# Altium schematic sheet AVR Programmer.SchDoc
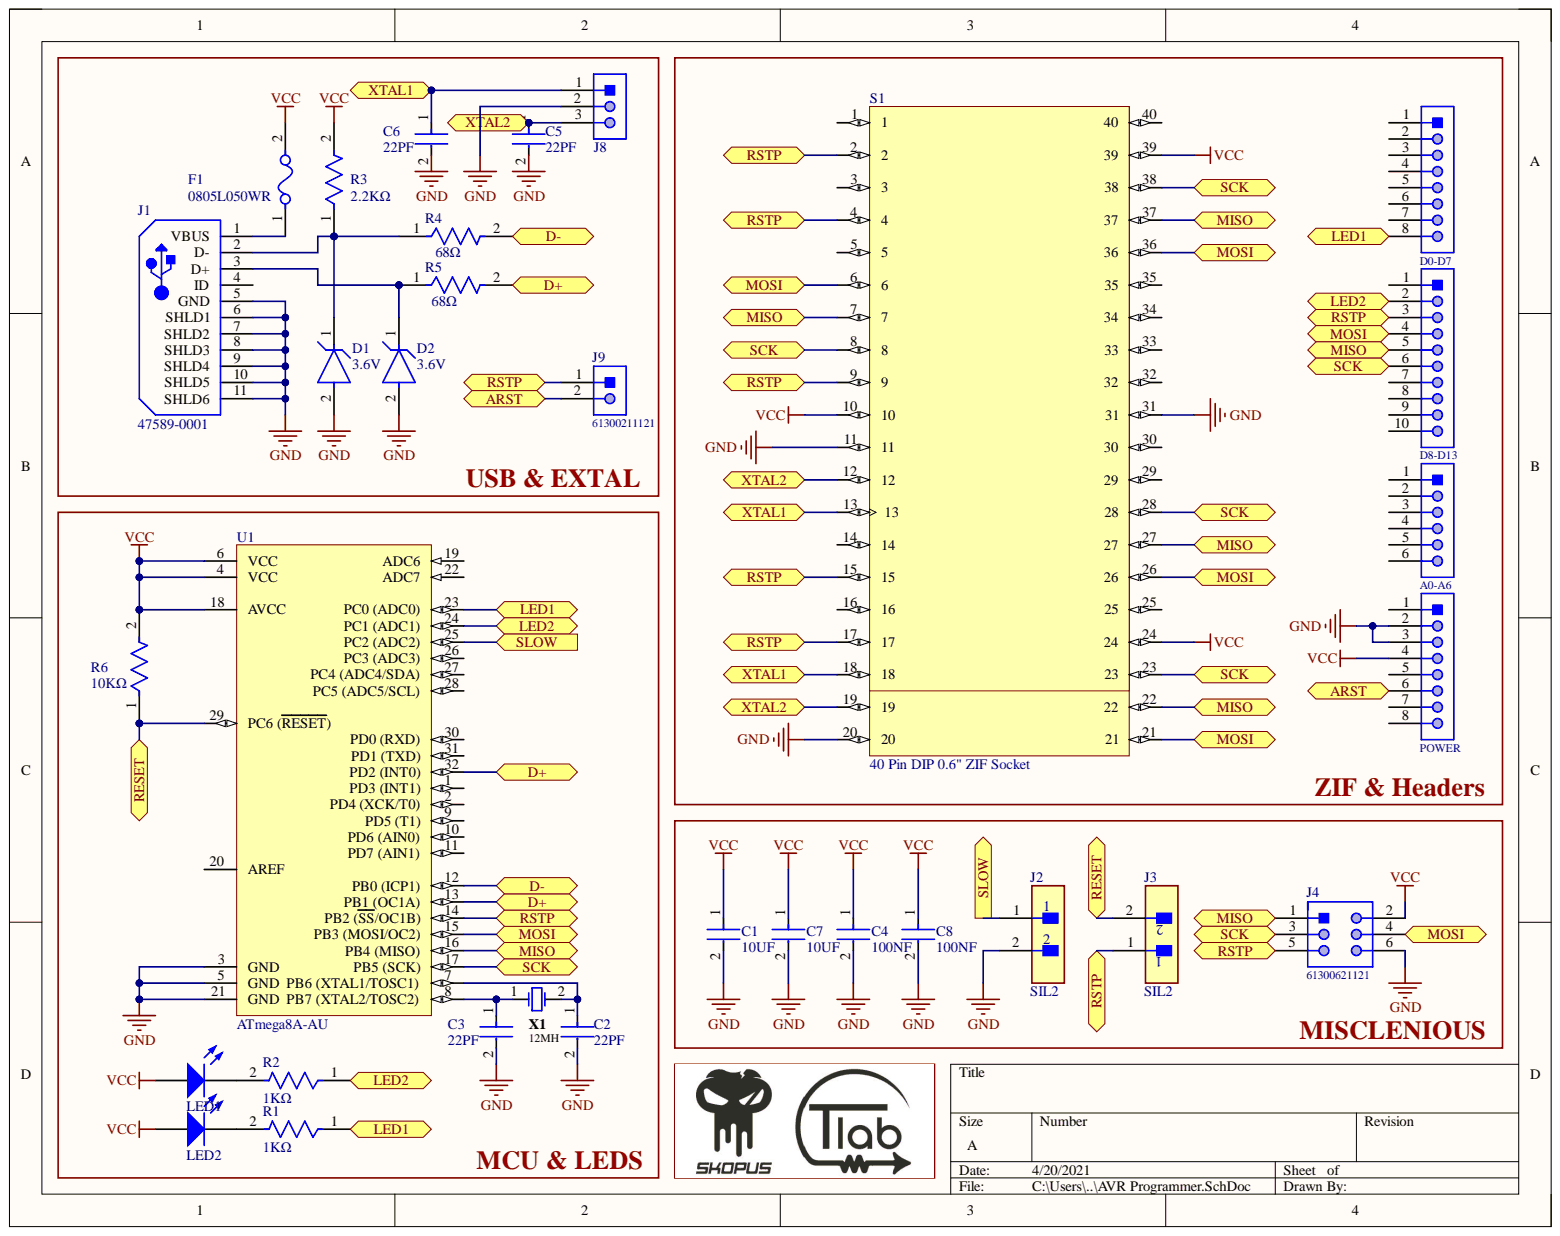
[diagram p0: AVR Programmer.SchDoc]

## Parts
C1  10UF  [FP-C0805C-DN-MFG]
C2  22PF  [FP-CC0805-0_85-MFG]
C3  22PF  [FP-CC0805-0_85-MFG]
C4  100NF  [FP-C0805C-DN-MFG]
C5  22PF  [FP-CC0805-0_85-MFG]
C6  22PF  [FP-CC0805-0_85-MFG]
C7  10UF  [FP-C0805C-DN-MFG]
C8  100NF  [FP-C0805C-DN-MFG]
D1  3.6V  [FP-6_560-5005_01-4-MFG]
D2  3.6V  [FP-6_560-5005_01-4-MFG]
F1  0805L050WR  [FP-0805L-MFG]
J1  47589-0001  [MOLX-47589-0001_V]
J2  SIL2  [FP-M22-2510205-MFG]
J3  SIL2  [FP-M22-2510205-MFG]
J4  D0-D7  [61300811121]
J4  61300621121  [61300621121]
J5  D8-D13  [61301011121]
J6  A0-A6  [61300611121]
J7  POWER  [61300811121]
J8  61300311121  [61300311121]  (value not drawn)
J9  61300211121  [61300211121]
LED1  (no value)  [0805_A]
LED2  150080SS75000  [0805_A]  (value not drawn)
R1  1KΩ  [FP-CRCW0805-e3-IPC_C]
R2  1KΩ  [FP-CRCW0805-e3-IPC_C]
R3  2.2KΩ  [FP-CRCW0805-e3-IPC_C]
R4  68Ω  [FP-CRCW0805-e3-IPC_C]
R5  68Ω  [FP-CRCW0805-e3-IPC_C]
R6  10KΩ  [FP-CRCW0805-e3-IPC_C]
S1  40 Pin DIP 0.6" ZIF Socket  [40P6]
U1  ATmega8A-AU  [32A_N]
X1  12MH  [FOX-FOXSLF-2_V]

## Ports
ARST, D+, D-, LED1, LED2, MISO, MOSI, RESET, RSTP, SCK, SLOW, XTAL1, XTAL2

## Nets
GND: C1.2 C2.2 C3.2 C4.2 C5.2 C6.2 C7.2 C8.2 D1.2 D2.2 J1.5(GND) J1.6(SHLD1) J1.7(SHLD2) J1.8(SHLD3) J1.9(SHLD4) J1.10(SHLD5) J1.11(SHLD6) J2.2 J4.6 J7.2 J7.3 J8.2 S1.11 S1.20 S1.31 U1.3(GND) U1.5(GND) U1.21(GND)
VCC: C1.1 C4.1 C7.1 C8.1 F1.2 J4.2 J7.4 LED1.2 LED2.2 R3.2 R6.2 S1.10 S1.24 S1.39 U1.4(VCC) U1.6(VCC) U1.18(AVCC)
ARST: J7.6 J9.2 ; port ARST
LED1: J4.8 R1.1 U1.23(PC0_(ADC0)) ; port LED1
LED2: J5.2 R2.1 U1.24(PC1_(ADC1)) ; port LED2
MISO: J4.1 J5.5 S1.7 S1.22 S1.27 S1.37 U1.16(PB4_(MISO)) ; port MISO
MOSI: J4.4 J5.4 S1.6 S1.21 S1.26 S1.36 U1.15(PB3_(MOSI/OC2)) ; port MOSI
RESET: J3.2 R6.1 U1.29(PC6_(RESET)) ; port RESET
RSTP: J3.1 J4.5 J5.3 J9.1 S1.2 S1.4 S1.9 S1.15 S1.17 U1.14(PB2_(SS/OC1B)) ; port RSTP
SCK: J4.3 J5.6 S1.8 S1.23 S1.28 S1.38 U1.17(PB5_(SCK)) ; port SCK
SLOW: J2.1 U1.25(PC2_(ADC2)) ; port SLOW
XTAL1: C6.1 J8.1 S1.13 S1.18 ; port XTAL1
XTAL2: C5.1 J8.3 S1.12 S1.19 ; port XTAL2
NetC2_1: C2.1 U1.7 X1.2
NetC3_1: C3.1 U1.8 X1.1
NetD1_1: D1.1 J1.2(D-) R3.1 R4.1
NetD2_1: D2.1 J1.3(D+) R5.1
NetF1_1: F1.1 J1.1(VBUS)
NetLED1_1: LED1.1 R2.2
NetLED2_1: LED2.1 R1.2
NetR4_2: R4.2 U1.12(PB0_(ICP1))
NetR5_2: R5.2 U1.13(PB1_(OC1A)) U1.32(PD2_(INT0))

Not connected: J1 4(ID); J4 7; J5 1 7 8 9 10; J6 1 2 3 4 5 6; J7 1 5 7 8; S1 1 3 5 14 16 25 29 30 32 33 34 35 40; U1 1(PD3_(INT1)) 2(PD4_(XCK/T0)) 9(PD5_(T1)) 10(PD6_(AIN0)) 11(PD7_(AIN1)) 19(ADC6) 20(AREF) 22(ADC7) 26(PC3_(ADC3)) 27(PC4_(ADC4/SDA)) 28(PC5_(ADC5/SCL)) 30(PD0_(RXD)) 31(PD1_(TXD))

## Library data of the parts
C1 C7 = CMP-2007-00235-2: CAP CER 1000PF 50V C0G/NP0 0805; Manufacturer: KEMET; Manufacturer Part Number: C0805C102J5GACTU; Capacitance: 1,000 pF; Material: Ceramic; Temperature Characteristic: C0G; Temperature Coefficient: 30ppm/°C; Tolerance: 0.05; Value: 1,000 pF; Automotive: No; Category: Cap; Component Kind: Standard; ComponentLink2Description: Datasheet; ComponentLink2URL: https://content.kemet.com/datasheets/KEM_C1003_C0G_SMD.pdf; Device Class L1: Passive Components; Device Class L2: Capacitors; Device Class L3: Ceramic Capacitors; Digikey Description: CAP CER 1000PF 50V C0G/NP0 0805; Height: 0.88mm; Ipc Land Pattern Name: CAPC200125X78; Lead Free: Yes; Library Name: Altium Content Vault; Mouser Description: Multilayer Ceramic Capacitors MLCC - SMD/SMT 50volts 1000pF C0G 5%; Octopart: https://octopart.com/c0805c102j5gactu-kemet-97757; Package: C0805C-DN; RoHS: TRUE; Temperature: -55°C to +125°C; Temperature Range High: +125°C; Temperature Range Low: -55°C; Voltage Rating: 50 V
C2 C3 C5 C6 = CMP-2100-03606-3: CAP CER 0.1UF 50V X7R 0805; Manufacturer: Yageo; Manufacturer Part Number: CC0805KRX7R9BB104; Capacitance: 100 nF; Material: Ceramic; Tolerance: 0.1; Value: 100 nF; Automotive: No; Category: Cap; Component Kind: Standard; ComponentLink2Description: Datasheet; ComponentLink2URL: http://www.yageo.com/documents/recent/UPY-GPHC_Y5V_6.3V-to-50V_8.pdf; Device Class L1: Passive Components; Device Class L2: Capacitors; Device Class L3: Ceramic Capacitors; Digikey Description: CAP CER 0.1UF 50V X7R 0805; Footprint Url: http://www.yageo.com/exep/pages/download/literatures/UPY-C_GEN_24.pdf; Height: 0.95mm; Ipc Land Pattern Name: CAPC200125X85; Lead Free: Yes; Library Name: Altium Content Vault; Mouser Description: Multilayer Ceramic Capacitors MLCC - SMD/SMT 100nF 50V X7R 10%; Octopart: https://octopart.com/cc0805krx7r9bb104-yageo-192993; Package: CC0805-0.85; RoHS: TRUE; Temperature: -30°C to +85°C; Temperature Characteristic: X7R; Temperature Range High: +85°C; Temperature Range Low: -30°C; Voltage Rating: 50 V
C4 C8 = CMP-2007-00403-2: CAP CER 680PF 50V X7R 0805; Manufacturer: KEMET; Manufacturer Part Number: C0805C681K5RACTU; Material: Ceramic; Temperature Coefficient: 30ppm/°C; Tolerance: 0.1; Automotive: No; Capacitance: 680 pF; Category: Cap; Component Kind: Standard; ComponentLink2Description: Datasheet; ComponentLink2URL: https://content.kemet.com/datasheets/KEM_C1003_C0G_SMD.pdf; Device Class L1: Passive Components; Device Class L2: Capacitors; Device Class L3: Ceramic Capacitors; Digikey Description: CAP CER 680PF 50V X7R 0805; Height: 0.88mm; Ipc Land Pattern Name: CAPC200125X78; Lead Free: Yes; Library Name: Altium Content Vault; Mouser Description: 0805 C 680pF Ceramic Multilayer Capacitor; 50 VDC; +125degC; X7R Dielec; +/-10%; Octopart: https://octopart.com/c0805c681k5ractu-kemet-124671; Package: C0805C-DN; RoHS: TRUE; Temperature: -55°C to +125°C; Temperature Characteristic: X7R; Temperature Range High: +125°C; Temperature Range Low: -55°C; Value: 680 pF; Voltage Rating: 50 V
D1 D2 = CMP-02541-000010-1: DIODE ZENER 5.1V 500MW SOD80; Manufacturer: Vishay Semiconductor Diodes Division; Manufacturer Part Number: TZMC5V1-GS08; Automotive Grade: Grade 0; Forward Current: 200mA; Max Junction Temp: +175°C; Max Reverse Leakage Current: 0.1uA; Max Zener Voltage: 5.4V; Reverse Voltage: 1V; Zener Impedance: 60Ω; Automotive: Yes; Category: Diode; Component Kind: Standard; ComponentLink2Description: Datasheet; ComponentLink2URL: https://www.vishay.com/docs/84122/tzm.pdf; Device Class L1: Discrete Semiconductors; Device Class L2: Diodes; Device Class L3: Zener Diodes; Digikey Description: DIODE ZENER 5.1V 500MW SOD80; Height: 1.6mm; Lead Free: Yes; Library Name: Altium Content Vault; Mouser Description: TZM Series 500 mW 5.1 V 200 mA Small Signal Zener Diode - SOD-80; Nom Zener Voltage: 5.1V; Octopart: https://octopart.com/tzmc5v1-gs08-vishay-1003274; Package: 6.560-5005.01-4; Power Dissipation: 500mW; RoHS: TRUE; Temperature: to +175°C; Temperature Range High: +175°C
F1 = CMP-08606-000023-1: PTC RESET FUSE 6V 500MA 0805; Manufacturer: Littelfuse Inc.; Current Rating: 100A; Hold Current: 0.5A; Resistance: 0.15-0.85Ω; Trip Current: 1A; Trip Time: 0.1s; Value: 0.15-0.85Ω; Ambient Temperature Range High: +85°C; Ambient Temperature Range Low: -40°C; Automotive: No; Category: Fuse; Component Kind: Standard; ComponentLink2Description: Datasheet; ComponentLink2URL: https://www.littelfuse.com/~/media/electronics/datasheets/resettable_ptcs/littelfuse_ptc_0805l_datasheet.pdf.pdf; Device Class L1: Circuit Protection; Device Class L2: PTC Resettable Fuses; Device Class L3: unset; Digikey Description: PTC RESET FUSE 6V 500MA 0805; Height: 1.25mm; Lead Free: Yes; Library Name: Altium Content Vault; Manufacturer Part Number: 0805L050WR; Mouser Description: PTC Resettable Fuse 0.5A(hold) 1A(trip) 6VDC 100A 0.5W 0.1s 0.15Ohm SMD Solder Pad 0805 T/R; Octopart: https://octopart.com/0805l050wr-littelfuse-673858; Package: 0805L; RoHS: TRUE; Temperature: -40°C to +85°C; Temperature Range High: +85°C; Temperature Range Low: -40°C; Voltage Rating: 6V
J1 = CMP-2000-05610-1: Right Angle Female Type AB Micro USB Connector, 30 V, 1.8 A, -30 to 85 degC, RoHS, Tape and Reel; Brand: Molex; Connector Type: MicroUSBTypeABreceptacle; Current Rating: 1.8A; Number of Contacts: 5Contact; Number of Ports: 1Port; Voltage Rating: 30VAC; : 0475890001; Color: Black; Component Kind: Standard; Contact Material: CopperAlloy; Contact Plating: Gold; Datasheet URL: http://www.molex.com/webdocs/datasheets/pdf/en-us/0475890001_IO_CONNECTORS.pdf; Datasheet Version: 12/2016; Gender: Female; Library Name: Altium Content Vault; Manufacturer: Molex; Manufacturer URL: http://www.molex.com/; Mounting Angle: Right; Mounting Technology: SurfaceMount; Operating Temperature Range: -20Cto+85C; Package Description: Micro USB Connector, Pitch 0.65 mm, 5 Positions, Body 7.5 x 5.6 mm; Package Reference: 47589-0001; Package URL: http://www.molex.com/pdm_docs/sd/475890001_sd.pdf; Packaging: Reel; Product: MicroUSBTypeABConnectors; Product Category: USBConnectors; RoHS: True; Series: 47589; Standard: USB; Termination Style: SMD/SMT
J2 J3 = CMP-15831-000005-1: CONN HEADER VERT 2POS 2MM; Manufacturer: Harwin; Manufacturer Part Number: M22-2510205; Conn Gender: Male; Conn Orientation: Vertical; Current Rating: 2A; Number Of Contacts: 2; Automotive: No; Category: Conn; Component Kind: Standard; ComponentLink2Description: Datasheet; ComponentLink2URL: https://cdn.harwin.com/pdfs/C018XX_M22_Series_Connectors.pdf; Contact Material: Gold,Nickel; Device Class L1: Connectors; Device Class L2: Headers and Wire Housings; Device Class L3: unset; Digikey Description: CONN HEADER VERT 2POS 2MM; Footprint Url: https://cdn.harwin.com/pdfs/M22-251.pdf; Height: 5.45mm; Lead Free: Yes; Library Name: Altium Content Vault; Mouser Description: Headers & Wire Housings 2 PIN SIL VERTICAL GOLD PIN HEADER; Number Of Rows: 1; Octopart: https://octopart.com/m22-2510205-harwin-2538221; Package: 0; Pitch: 2mm; RoHS: TRUE; Temperature: -40°C to +105°C; Temperature Range High: +105°C; Temperature Range Low: -40°C
J4 J7 = CMP-1502-01076-1: THT Vertical Pin Header WR-PHD, Pitch 2.54 mm, Single Row, 8 pins; Manufacturer: Wurth Elektronik; Contact Resistance: 20mOhm; Gender: Male; Pin Number: 8; Pitch: 2.54mm; Rated Current: 3A; Withstanding Voltage: 500VAC; Working Voltage: 250VAC; Component Kind: Standard; Datasheet URL: https://katalog.we-online.de/em/datasheet/6130xx11121.pdf; Library Name: Altium Content Vault; Manufacturer URL: http://www.we-online.de; Mounting Technology: THT; Operating Temperature: -40to105degC; Orientation: Vertical; Package Reference: 61300811121; Packaging: Bag; Part Number: 61300811121; Row Number: Single; Publisher: WurthElektronik
J4 = CMP-1502-01072-1: THT Vertical Pin Header WR-PHD, Pitch 2.54 mm, Dual Row, 6 pins; Manufacturer: Wurth Elektronik; Contact Resistance: 20mOhm; Gender: Male; Pin Number: 6; Pitch: 2.54mm; Rated Current: 3A; Withstanding Voltage: 500VAC; Working Voltage: 250VAC; Component Kind: Standard; Datasheet URL: https://katalog.we-online.de/em/datasheet/6130xx21121.pdf; Library Name: Altium Content Vault; Manufacturer URL: http://www.we-online.de; Mounting Technology: THT; Operating Temperature: -40to105degC; Orientation: Vertical; Package Reference: 61300621121; Packaging: Bag; Part Number: 61300621121; Row Number: Dual; Publisher: WurthElektronik
J5 = CMP-1502-01082-1: THT Vertical Pin Header WR-PHD, Pitch 2.54 mm, Single Row, 10 pins; Manufacturer: Wurth Elektronik; Contact Resistance: 20mOhm; Gender: Male; Pin Number: 10; Pitch: 2.54mm; Rated Current: 3A; Withstanding Voltage: 500VAC; Working Voltage: 250VAC; Component Kind: Standard; Datasheet URL: https://katalog.we-online.de/em/datasheet/6130xx11121.pdf; Library Name: Altium Content Vault; Manufacturer URL: http://www.we-online.de; Mounting Technology: THT; Operating Temperature: -40to105degC; Orientation: Vertical; Package Reference: 61301011121; Packaging: Bag; Part Number: 61301011121; Row Number: Single; Publisher: WurthElektronik
J6 = CMP-1502-01070-1: THT Vertical Pin Header WR-PHD, Pitch 2.54 mm, Single Row, 6 pins; Manufacturer: Wurth Elektronik; Contact Resistance: 20mOhm; Gender: Male; Pin Number: 6; Pitch: 2.54mm; Rated Current: 3A; Withstanding Voltage: 500VAC; Working Voltage: 250VAC; Component Kind: Standard; Datasheet URL: https://katalog.we-online.de/em/datasheet/6130xx11121.pdf; Library Name: Altium Content Vault; Manufacturer URL: http://www.we-online.de; Mounting Technology: THT; Operating Temperature: -40to105degC; Orientation: Vertical; Package Reference: 61300611121; Packaging: Bag; Part Number: 61300611121; Row Number: Single; Publisher: WurthElektronik
J8 = CMP-1502-01062-1: THT Vertical Pin Header WR-PHD, Pitch 2.54 mm, Single Row, 3 pins; Manufacturer: Wurth Elektronik; Contact Resistance: 20mOhm; Gender: Male; Pin Number: 3; Pitch: 2.54mm; Rated Current: 3A; Withstanding Voltage: 500VAC; Working Voltage: 250VAC; Component Kind: Standard; Datasheet URL: https://katalog.we-online.de/em/datasheet/6130xx11121.pdf; Library Name: Altium Content Vault; Manufacturer URL: http://www.we-online.de; Mounting Technology: THT; Operating Temperature: -40to105degC; Orientation: Vertical; Package Reference: 61300311121; Packaging: Bag; Part Number: 61300311121; Row Number: Single; Publisher: WurthElektronik
J9 = CMP-1712-00002-4: THT Vertical Pin Header WR-PHD, Pitch 2.54 mm, Single Row, 2 pins; Manufacturer: Wurth Elektronik; Contact Resistance: 20mOhm; Gender: Male; Pin Number: 2; Pitch: 2.54mm; Rated Current: 3A; Withstanding Voltage: 500VAC; Working Voltage: 250VAC; Component Kind: Standard; Datasheet URL: https://katalog.we-online.de/em/datasheet/6130xx11121.pdf; Library Name: Altium Content Vault; Manufacturer URL: http://www.we-online.de; Mounting Technology: THT; Operating Temperature: -40to105degC; Orientation: Vertical; Package Reference: 61300211121; Packaging: Bag; Part Number: 61300211121; Row Number: Single; Publisher: WurthElektronik
LED1 = CMP-1426-00010-1: SMD mono-color Chip LED, WL-SMCW, Super Red; Manufacturer: Wuerth Elektronik; PartNumber: 150080SS75000; Color: Super Red; Component Kind: Standard; ComponentLink1Description: Manufacturer URL; ComponentLink1URL: http://www.we-online.com; ComponentLink2Description: Datasheet; ComponentLink2URL: http://katalog.we-online.com/led/datasheet/150080SS75000.pdf; Library Name: Altium Content Vault; PackageReference: 0805_A
LED2 = CMP-1426-00010-1: SMD mono-color Chip LED, WL-SMCW, Super Red; Manufacturer: Wuerth Elektronik; Color: Super Red; Component Kind: Standard; ComponentLink1Description: Manufacturer URL; ComponentLink1URL: http://www.we-online.com; ComponentLink2Description: Datasheet; ComponentLink2URL: http://katalog.we-online.com/led/datasheet/150080SS75000.pdf; Library Name: Altium Content Vault; PackageReference: 0805_A; PartNumber: 150080SS75000
R1-R4 R6 = CMP-2001-04979-2: RES Thick Film, 68Ω, 1%, 0.125W, 100ppm/°C, 0805; Max Power Dissipation: 125mW; Resistance: 16kR; Voltage Rating: 150V; Case/Package: 0805; Case Code (Imperial): 0805; Case Code (Metric): 2012; Component Kind: Standard; Composition: Thick Film; Contact Plating: Tin; Depth: 1.25mm; Features: Automotive AEC-Q200; Height: 0.45mm; Lead Free: Lead Free; Length: 2mm; Library Name: Altium Content Vault; Max Operating Temperature: 155°C; Military Standard: Not; Min Operating Temperature: -55°C; Mount: Surface Mount; Number of Pins: 2; Number of Terminations: 2; Package Quantity: 10; Packaging: Tape and Reel; Power Rating: 125mW; Radiation Hardening: No; Temperature Coefficient: 100ppm/°C; Termination: SMD/SMT; Tolerance: 1%; Voltage Rating (DC): 150V; Weight: 0.000194oz; Width: 1.25mm
R5 = CMP-2001-04979-2: RES Thick Film, 16kΩ, 1%, 0.125W, 100ppm/°C, 0805; Max Power Dissipation: 125mW; Resistance: 16kR; Voltage Rating: 150V; Case/Package: 0805; Case Code (Imperial): 0805; Case Code (Metric): 2012; Component Kind: Standard; Composition: Thick Film; Contact Plating: Tin; Depth: 1.25mm; Features: Automotive AEC-Q200; Height: 0.45mm; Lead Free: Lead Free; Length: 2mm; Library Name: Altium Content Vault; Max Operating Temperature: 155°C; Military Standard: Not; Min Operating Temperature: -55°C; Mount: Surface Mount; Number of Pins: 2; Number of Terminations: 2; Package Quantity: 10; Packaging: Tape and Reel; Power Rating: 125mW; Radiation Hardening: No; Temperature Coefficient: 100ppm/°C; Termination: SMD/SMT; Tolerance: 1%; Voltage Rating (DC): 150V; Weight: 0.000194oz; Width: 1.25mm
S1 = CMP-0095-00014-2: 8-bit AVR Microcontroller, 32KB Flash, 1KB EEPROM, 2KB SRAM, 40-pin PDIP, Industrial Grade (-40°C to 85°C); Code_JEDEC: MS-011-AC; Component Kind: Standard; ComponentLink1Description: Manufacturer URL; ComponentLink1URL: http://www.atmel.com/; ComponentLink2Description: ATmega164A/164PA/324A/324PA/644A/644PA/1284/1284P Datasheet; ComponentLink2URL: http://www.atmel.com/dyn/resources/prod_documents/doc8272.pdf; ComponentLink3Description: ATmega164A/164PA/324A/324PA/644A/644PA/1284/1284P Summary; ComponentLink3URL: http://www.atmel.com/dyn/resources/prod_documents/8272S.pdf; DatasheetVersion: revC, Jun-2011; Library Name: Altium Content Vault; PackageDescription: 40-lead (0.600"/15.24mm Wide) Plastic Dual Inline Package (PDIP); PackageReference: 40P6; PackageVersion: revB, Sep-2001; RevisionNote: DatasheetVersion & Sch pin names updated. URLs added.
U1 = CMP-0095-00219-2: 8-bit AVR Microcontroller, 2.7-5.5V, 16MHz, 8KB Flash, 512 Bytes EEPROM, 1KB SRAM, 32-pin TQFP, Industrial Grade (-40°C to 85°C); Code_JEDEC: MS-026-ABA; Component Kind: Standard; ComponentLink1Description: Manufacturer URL; ComponentLink1URL: http://www.atmel.com/; ComponentLink2Description: ATmega8A Datasheet; ComponentLink2URL: http://www.atmel.com/dyn/resources/prod_documents/doc8159.pdf; ComponentLink3Description: ATmega8A Summary; ComponentLink3URL: http://www.atmel.com/dyn/resources/prod_documents/8159S.pdf; DatasheetVersion: revD, Feb-2011; Library Name: Altium Content Vault; PackageDescription: 32-Lead, 7x7x1.0 mm Body, 0.8mm Lead Pitch, Thin Profile Plastic Quad Flat Package (TQFP); PackageReference: 32A; PackageVersion: revC, Oct-2010; RevisionNote: DatasheetVersion & PackageVersion updated. URLs added.
X1 = CMP-1749-00004-1: Resistance Weld Thru-Hole Crystal, 12 MHz, +/-30 ppm, -20 to 70 degC, 2-Pin THD, RoHS, Bulk; Case\Package: TO-251-3; Elements: 1; Input Capacitance: 350 pF; Max Power Dissipation: 2.5 W; Rds On Max: 115 mohm; Typical Rise Time: 90 ns; Component Kind: Standard; ComponentLink1Description: Manufacturer URL; ComponentLink1URL: http://www.foxonline.com/; ComponentLink2Description: Datasheet; ComponentLink2URL: http://www.foxonline.com/pdfs/hc49slf.pdf; DatasheetVersion: Mar-15; Height: 6.1 mm; Length: 6.6 mm; Library Name: Altium Content Vault; Max Operating Temperature: 150 degC; Min Operating Temperature: -55 degC; Mount: Through Hole; PackageDescription: 2-Pin Through Hole Device, Body 11.35 x 4.65 mm, Pitch 4.88 mm; Package Quantity: 70; PackageReference: FOXSLF-2; Packaging: Rail/Tube; Pins: 3; Radiation Hardening: No; REACH SVHC: No SVHC; RoHSCompliant: true; Width: 2.3 mm
